# Altium schematic sheet Waspmoteprint_Diff_zu_I2C.SchDoc
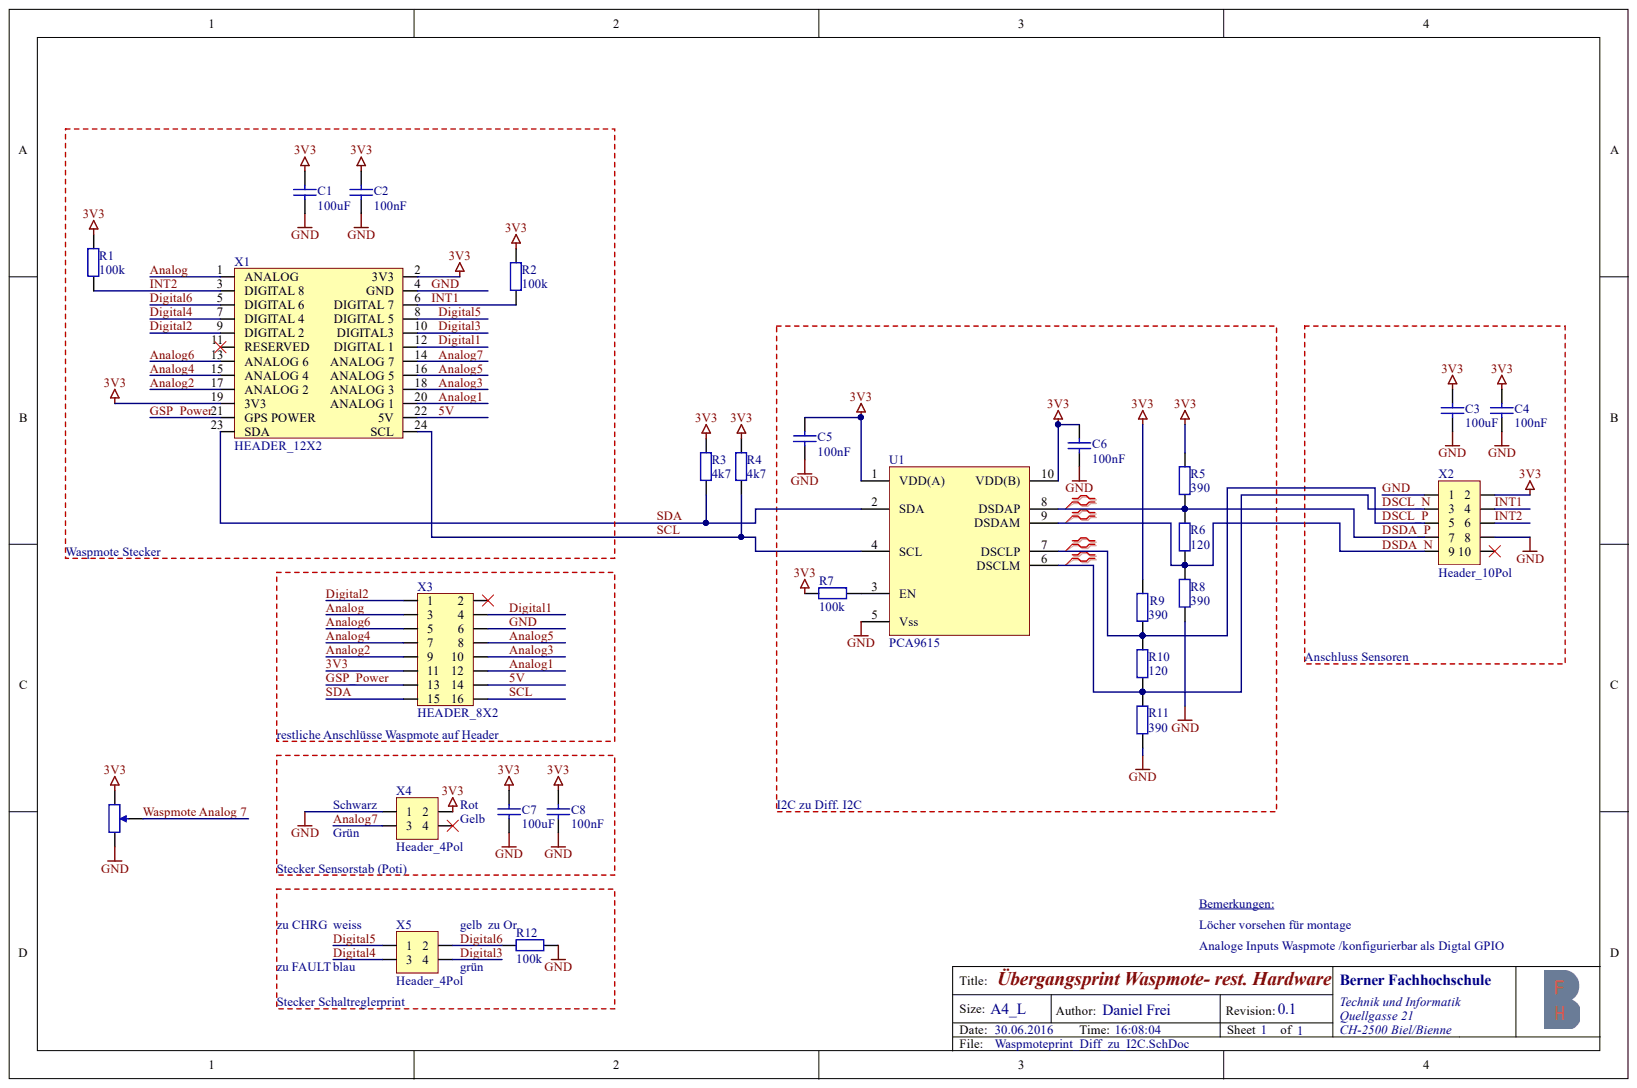
[diagram p0: Waspmoteprint_Diff_zu_I2C.SchDoc]

## Parts
C1  100uF  [CAPC3225[1210]X120]
C2  100nF  [CAPC1005[0402]X50]
C3  100uF  [CAPC3225[1210]X120]
C4  100nF  [CAPC1005[0402]X50]
C5  100nF  [CAPC1005[0402]X50]
C6  100nF  [CAPC1005[0402]X50]
C7  100uF  [CAPC3225[1210]X120]
C8  100nF  [CAPC1005[0402]X50]
R1  100k  [RESC1005[0402]X35]
R2  100k  [RESC1005[0402]X35]
R3  4k7  [RESC1005[0402]X35]
R4  4k7  [RESC1005[0402]X35]
R5  390  [RESC1005[0402]X35]
R6  120  [RESC1005[0402]X35]
R7  100k  [RESC1005[0402]X35]
R8  390  [RESC1005[0402]X35]
R9  390  [RESC1005[0402]X35]
R10  120  [RESC1005[0402]X35]
R11  390  [RESC1005[0402]X35]
R12  100k  [RESC1005[0402]X35]
U1  PCA9615  [TSSOP10]
X1  HEADER_12X2  [HDR2X12_V_M]
X2  Header_10Pol  [Header_2mm_10Pol]
X3  HEADER_8X2  [HDR2X8_V_M]
X4  Header_4Pol  [Header_2mm_4Pol]
X5  Header_4Pol  [Header_2mm_4Pol]
  (no value)  [BOURNS3296W]

## Nets
3V3: C1.2 C2.2 C3.2 C4.2 C5.2 C6.2 C7.2 C8.2 R1.2 R2.2 R3.2 R4.2 R5.2 R7.1 R9.2 U1.1(VDD(A)) U1.10(VDD(B)) X1.2(3V3) X1.19(3V3) X2.2 X3.11 X4.2 .3
5V: X1.22(5V) X3.14
ANALOG: X1.1(ANALOG) X3.3
ANALOG1: X1.20(ANALOG_1) X3.12
ANALOG2: X1.17(ANALOG_2) X3.9
ANALOG3: X1.18(ANALOG_3) X3.10
ANALOG4: X1.15(ANALOG_4) X3.7
ANALOG5: X1.16(ANALOG_5) X3.8
ANALOG6: X1.13(ANALOG_6) X3.5
ANALOG7: X1.14(ANALOG_7) X4.3
DIGITAL1: X1.12(DIGITAL_1) X3.4
DIGITAL2: X1.9(DIGITAL_2) X3.1
DIGITAL3: X1.10(DIGITAL3) X5.4
DIGITAL4: X1.7(DIGITAL_4) X5.3
DIGITAL5: X1.8(DIGITAL_5) X5.1
DIGITAL6: R12.2 X1.5(DIGITAL_6) X5.2
DSCL_N: R10.1 R11.2 U1.6(DSCLM) X2.3
DSCL_P: R9.1 R10.2 U1.7(DSCLP) X2.5
DSDA_N: R6.1 R8.2 U1.9(DSDAM) X2.9
DSDA_P: R5.1 R6.2 U1.8(DSDAP) X2.7
GND: C1.1 C2.1 C3.1 C4.1 C5.1 C6.1 C7.1 C8.1 R8.1 R11.1 R12.1 U1.5(Vss) X1.4(GND) X2.1 X2.8 X3.6 X4.1 .1
GSP_POWER: X1.21(GPS_POWER) X3.13
INT1: R2.1 X1.6(DIGITAL_7) X2.4
INT2: R1.1 X1.3(DIGITAL_8) X2.6
SCL: R4.1 U1.4(SCL) X1.24(SCL) X3.16
SDA: R3.1 U1.2(SDA) X1.23(SDA) X3.15
WASPMOTE ANALOG 7: .2
NetR7_2: R7.2 U1.3(EN)

Not connected: X1 11(RESERVED); X2 10; X3 2; X4 4

## Library data of the parts
C1 C3 C7 = C: Capacitor; Manufacturer: Murata; Manufacturer Part Number: GRM32ER61A107ME20L; Modified_by: BEP1; Component Kind: Standard; Library Name: RC_SMD_3D.SchLib; Modified: 10.2008; Supplier 1: Digi-Key; Supplier 2: Mouser; Supplier Part Number 1: 490-9969-1-ND; Supplier Part Number 2: 81-GRM32ER61A107ME0L; Value: 100uF
C2 C4-C6 C8 = C: Capacitor; Modified_by: BEP1; Component Kind: Standard; Library Name: RC_SMD_3D.SchLib; Modified: 10.2008; Value: 100nF
R1-R12 = R: Resistor; Modified_by: BEP1; Component Kind: Standard; Library Name: RC_SMD_3D.SchLib; Modified: 10.2008
  R1 R2 R7 R12: Value: 100k
  R3 R4: Value: 4k7
  R5 R8 R9 R11: Value: 390
  R6 R10: Value: 120
U1 = PCA9615: differential I2C-bus buffer; Manufacturer: NXP; Manufacturer Part Number: PCA9615DPJ; Component Kind: Standard; Supplier 1: Digi-Key; Supplier 2: Mouser; Supplier Part Number 1: 568-11484-1-ND; Supplier Part Number 2: 771-PCA9615DPJ; Value: PCA9615; Library Name: Sensorboard_Library.SchLib
X1 = HEADER_12X2: Header, 12-Pin, Dual row; Component Kind: Standard; Library Name: Sensorboard_Library.SchLib
X2 = Header_Hirose_10: Header Hirose 10 Pol; Manufacturer Part Number: DF11-10DP-2DSA(24); Component Kind: Standard; Manufacturer: Hirose; Supplier 1: Digi-Key; Supplier 2: Mouser; Supplier Part Number 1: H10232-ND; Supplier Part Number 2: 798-DF11-10DP-2DSA24; Value: Header_10Pol; Library Name: Sensorboard_Library.SchLib
X3 = HEADER_8X2: Header, 16-Pin, Dual row; Component Kind: Standard; Library Name: Sensorboard_Library.SchLib
X4 X5 = Header_Hirose_4: Header Hirose 4 Pol; Manufacturer Part Number: DF11-4DP-2DSA(24); Component Kind: Standard; Manufacturer: Hirose; Supplier 1: Digi-Key; Supplier 2: Mouser; Supplier Part Number 1: H10229-ND; Supplier Part Number 2: 798-DF11-4DP-2DSA24; Value: Header_4Pol; Library Name: Sensorboard_Library.SchLib
 = POT2: Variable Resistor; Component Kind: Standard; LatestRevisionDate: 10-July-2013; Library Name: RC_SMD_3D.SchLib; Publisher: Bep1
